# Altium schematic sheet Speaker_Switches.SchDoc
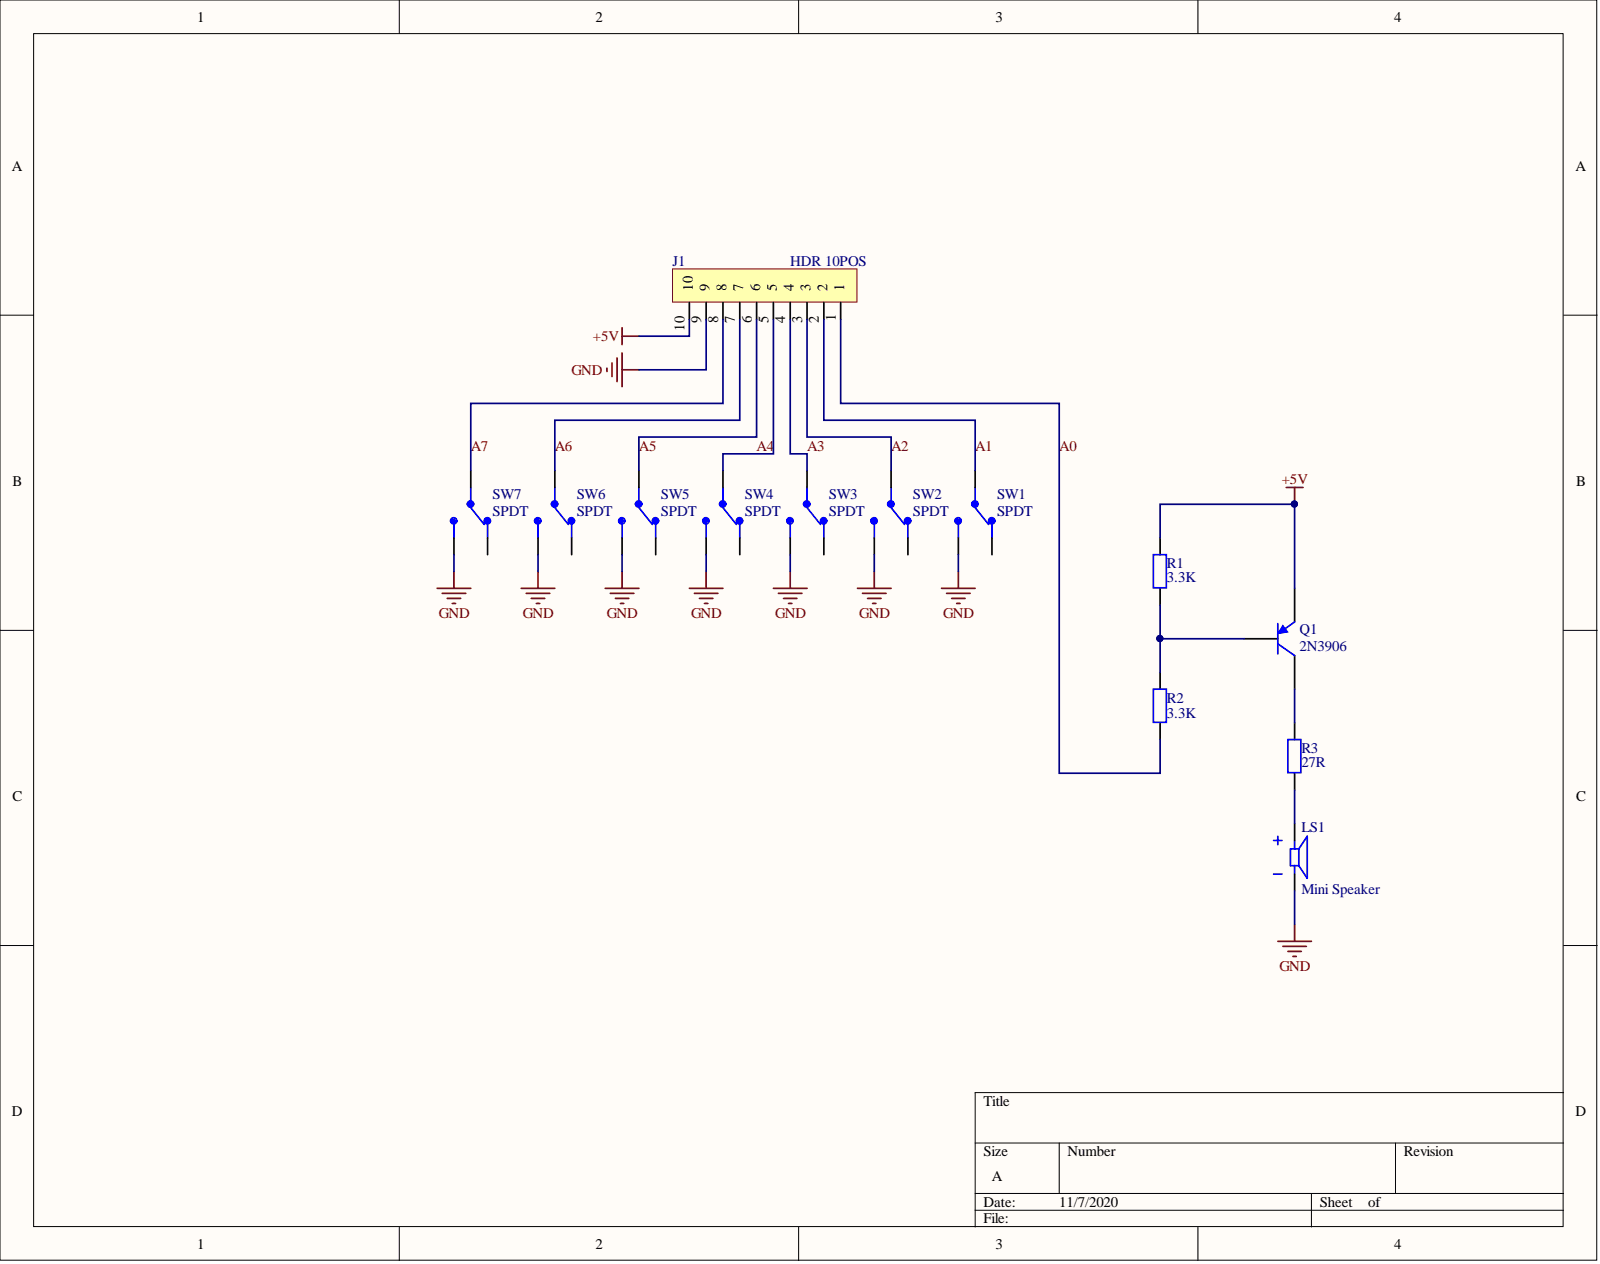
[diagram p0: Speaker_Switches.SchDoc]

## Parts
J1  HDR 10POS  [HDR 10 POS 2.54 FEMALE ST]
LS1  Mini Speaker  [Speaker  Adafruit 8 Ohm 0.2W TH 1898 30mm 15mm]
Q1  2N3906  [TO-92A]
R1  3.3K  [AXIAL-0.4]
R2  3.3K  [AXIAL-0.4]
R3  27R  [AXIAL-0.4]
SW1  SPDT  [SW_1218_EWI]
SW2  SPDT  [SW_1218_EWI]
SW3  SPDT  [SW_1218_EWI]
SW4  SPDT  [SW_1218_EWI]
SW5  SPDT  [SW_1218_EWI]
SW6  SPDT  [SW_1218_EWI]
SW7  SPDT  [SW_1218_EWI]

## Nets
+5V: J1.10 Q1.1 R1.2
GND: J1.9 LS1.N SW1.3 SW2.3 SW3.3 SW4.3 SW5.3 SW6.3 SW7.3
A0: J1.1 R2.1
A1: J1.2 SW1.2
A2: J1.3 SW2.2
A3: J1.4 SW3.2
A4: J1.5 SW4.2
A5: J1.6 SW5.2
A6: J1.7 SW6.2
A7: J1.8 SW7.2
NetLS1_P: LS1.P R3.1
NetQ1_2: Q1.2 R1.1 R2.2
NetQ1_3: Q1.3 R3.2

Not connected: SW1 1; SW2 1; SW3 1; SW4 1; SW5 1; SW6 1; SW7 1

## Library data of the parts
J1 = HDR 10 Pos 2.54mm female TH ST: Manufacturer Part Number 1: 90147-1110; Brand: Molex; Category 1: Headers & Wire Housings; Current Rating: 3 A; Description 1: Headers & Wire Housings C-Grid PCB Conn SR V onn SR Vt Tn-A 10Ckt; Mounting Angle: Vertical; Number of Positions: 10 Position; Voltage Rating: 350 V; Accessory Type: -; Component Kind: Standard; ComponentLink1Description: https://www.mouser.com/datasheet/2/276/1/0901471110_PCB_RECEPTACLES-176767.pdf; ComponentLink1URL: https://www.mouser.com/datasheet/2/276/1/0901471110_PCB_RECEPTACLES-176767.pdf; Contact Gender: Socket (Female); Contact Material: Tin; Contact Plating: Tin; Contact Type: -; Description: HDR 10 Pos 2.54mm female TH ST; Flammability Rating: UL 94 V-0; Housing Color: Black; Housing Gender: -; Housing Material: Polyester; Library Name: Schematic_Library.SchLib; Manufacturer 1: Molex; Maximum Operating Temperature: + 105 C; Minimum Operating Temperature: - 55 C; Minimum Order Quantity: 1; Mounting Style: Through Hole; Number of Rows: 1 Row; Packaging: Tube; Pitch: 2.54 mm; Pricing 1: 1=2.53, 10=2.06, 100=1.97, 250=1.78, 500=1.64, 1000=1.36, 2500=1.31, 5000=1.25 (USD); Product: Headers; Product Type: Headers & Wire Housings; Reeling: False; RoHS 1: RoHS Compliant; RoHS - Mouser: Y; Row Spacing: -; Series: 90147; Standard Pack Qty: 1760; Stock 1: 1869; Subcategory: Headers & Wire Housings; Supplier 1: Mouser; Supplier Part Number 1: 538-90147-1110; Termination Style: Through Hole; Tradename: C-Grid; Type: Socket
LS1 = Speaker - 8 Ohm 0.2W Breadboard-Friendly PCB Mount Mini Speaker TH: Breadboard-Friendly PCB Mount Mini Speaker - 8 Ohm 0.2W adafruit 1898 KS-3008; Component Kind: Standard; Library Name: Schematic_Library.SchLib; Supplier 1: Adafruit; Supplier Part Number 1: 1898
Q1 = 2N3906: PNP General Purpose Amplifier; Component Kind: Standard; ComponentLink1Description: Manufacturer Link; ComponentLink1URL: http://www.fairchildsemi.com/; ComponentLink2Description: Datasheet; ComponentLink2URL: http://www.fairchildsemi.com/ds/2N%2F2N3906.pdf; DatasheetVersion: 1997; LatestRevisionDate: 15-Jan-2003; LatestRevisionNote: PCB Footprint 'BCY-W3/D4.7' replaced; Library Name: Miscellaneous Devices.IntLib; PackageDescription: TO, Flat Index; 3 In-Line, Axial Leads; Body Dia. 4.5mm; Leads 0.51 x 0.48 mm (max); PackageReference: TO-92A; PackageVersion: Sep-1998; Published: 8-Jun-2000; Signal Integrity: 2N3906; Simulation: 2N3906; Publisher: Altium Limited
R1-R3 = Res2: Resistor; Component Kind: Standard; LatestRevisionDate: 17-Jul-2002; LatestRevisionNote: Re-released for DXP Platform.; Library Name: Miscellaneous Devices.IntLib; PackageDescription: Axial Device, Thru-Hole; 2 Leads; 0.4 in Pin Spacing; PackageReference: AXIAL-0.4; Published: 8-Jun-2000; Signal Integrity: Res; Simulation: RESISTOR; Publisher: Altium Limited
  R1 R2: Value: 3.3K
  R3: Value: 27R
SW1-SW7 = Slide Switch SPDT 30V 200mA TH ST: SWITCH SLIDE SPDT 200MA 30V; Manufacturer: E-Switch; 3D Model: EG1218.stp; Actuator Length: 2.00mm; Actuator Type: Standard; Catalog Drawings: EG1218; Contact Timing: Non-Shorting (BBM); Current Rating: 200mA (DC); Online Catalog: EG Series; Operating Force: 250gf; Other Names: EG1903 P040040; Product Training Modules: Switch Basics Power Switch Overview; Switch Function: On-On; Switch Travel: 2.50mm; Actuator Material: -; Category: Switches; Circuit: SPDT; Component Kind: Standard; ComponentLink1Description: http://spec.e-switch.com/P-J/P040040C.pdf?src-supplier=Newark; ComponentLink1URL: http://spec.e-switch.com/P-J/P040040C.pdf?src-supplier=Newark; Contact Finish: Silver; Contact Material: Copper Alloy; Electrical Life: -; Family: Slide Switches; Features: -; Library Name: Schematic_Library.SchLib; Manufacturer Part Number: EG1218; Mechanical Life: -; Mounting Type: Through Hole; Operating Temperature: -20°C ~ 70°C; Packaging: Bulk; Pricing 1: 1=0.65, 10=0.627, 25=0.6024, 100=0.523, 250=0.4772, 500=0.4434, 1000=0.3977, 2500=0.3736, 5000=0.347 (USD); Series: EG; Standard Package: 1,000; Stock 1: 36403; Supplier 1: Digi-Key; Supplier Part Number 1: EG1903-ND; Termination Style: PC Pin; Voltage Rating - AC: -; Voltage Rating - DC: 30V
